# Altium schematic sheet FPGA.SchDoc
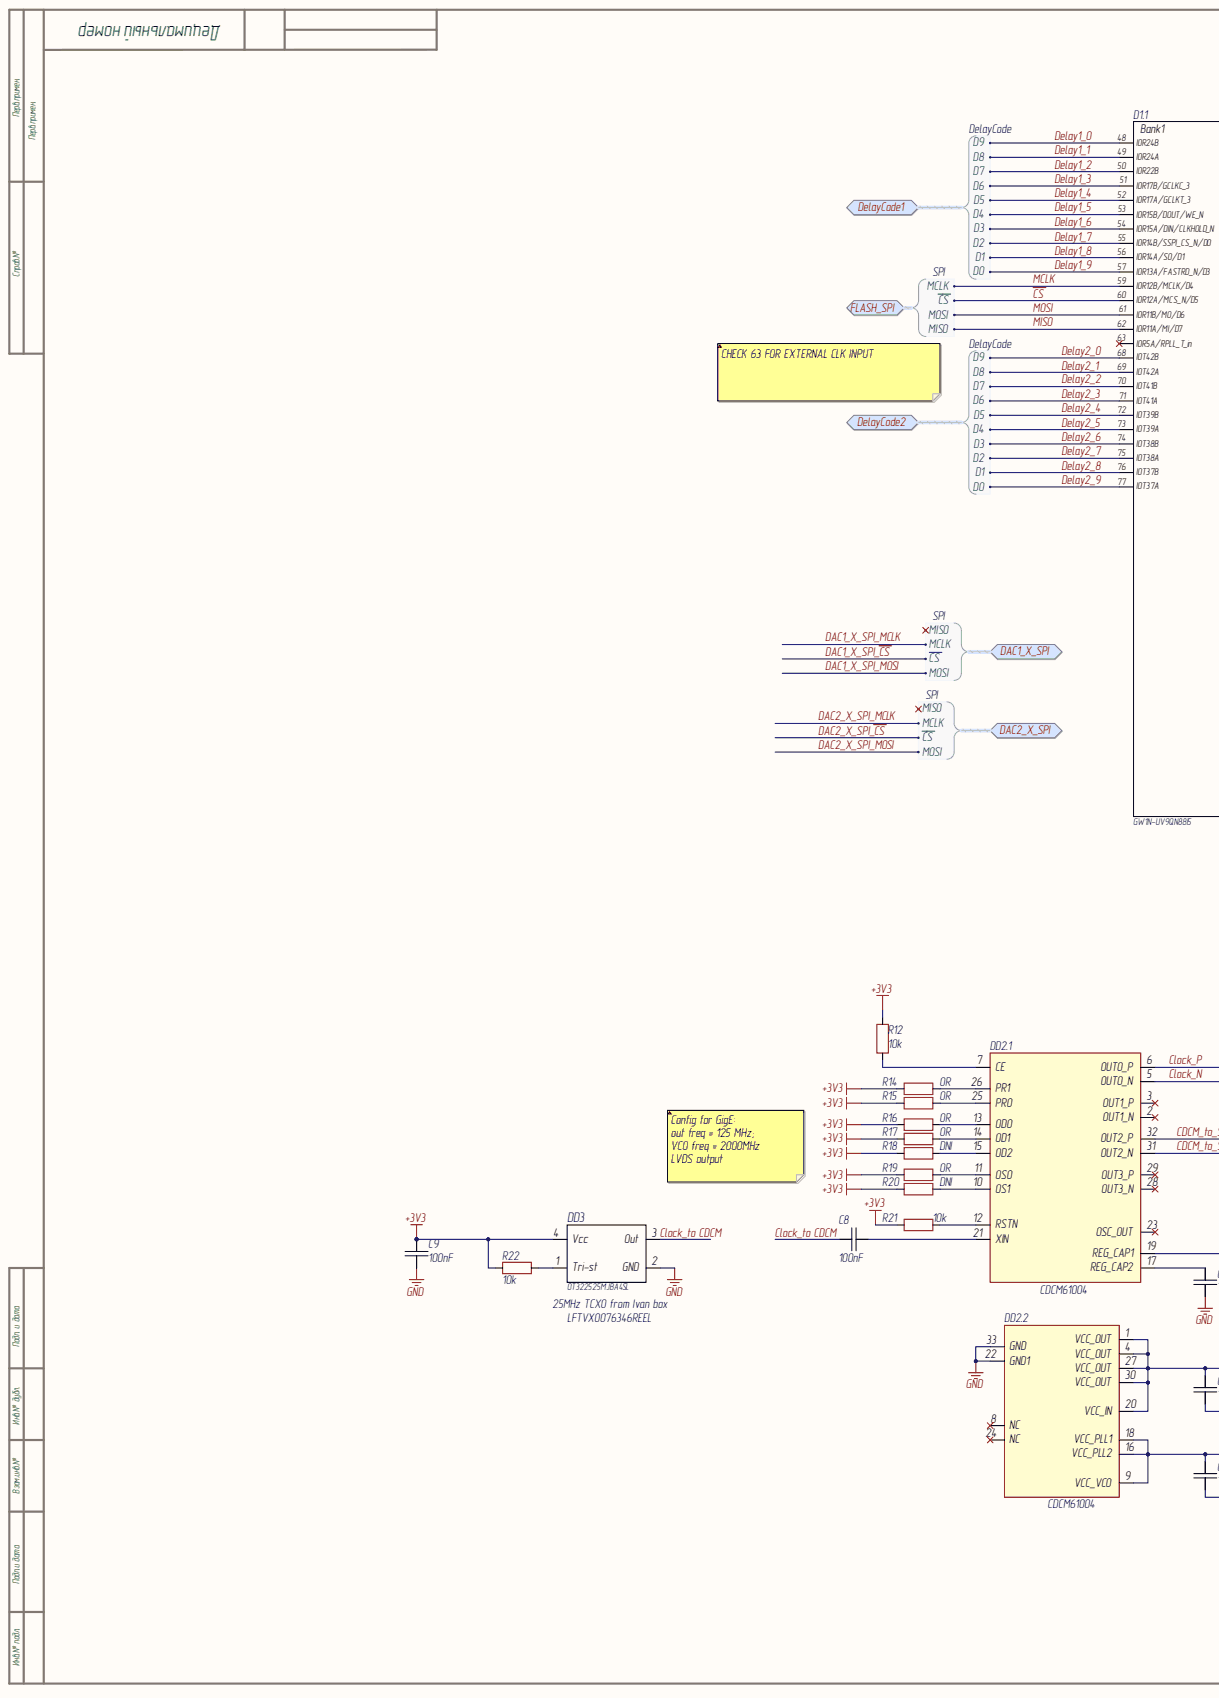
[diagram p0: FPGA.SchDoc, left]
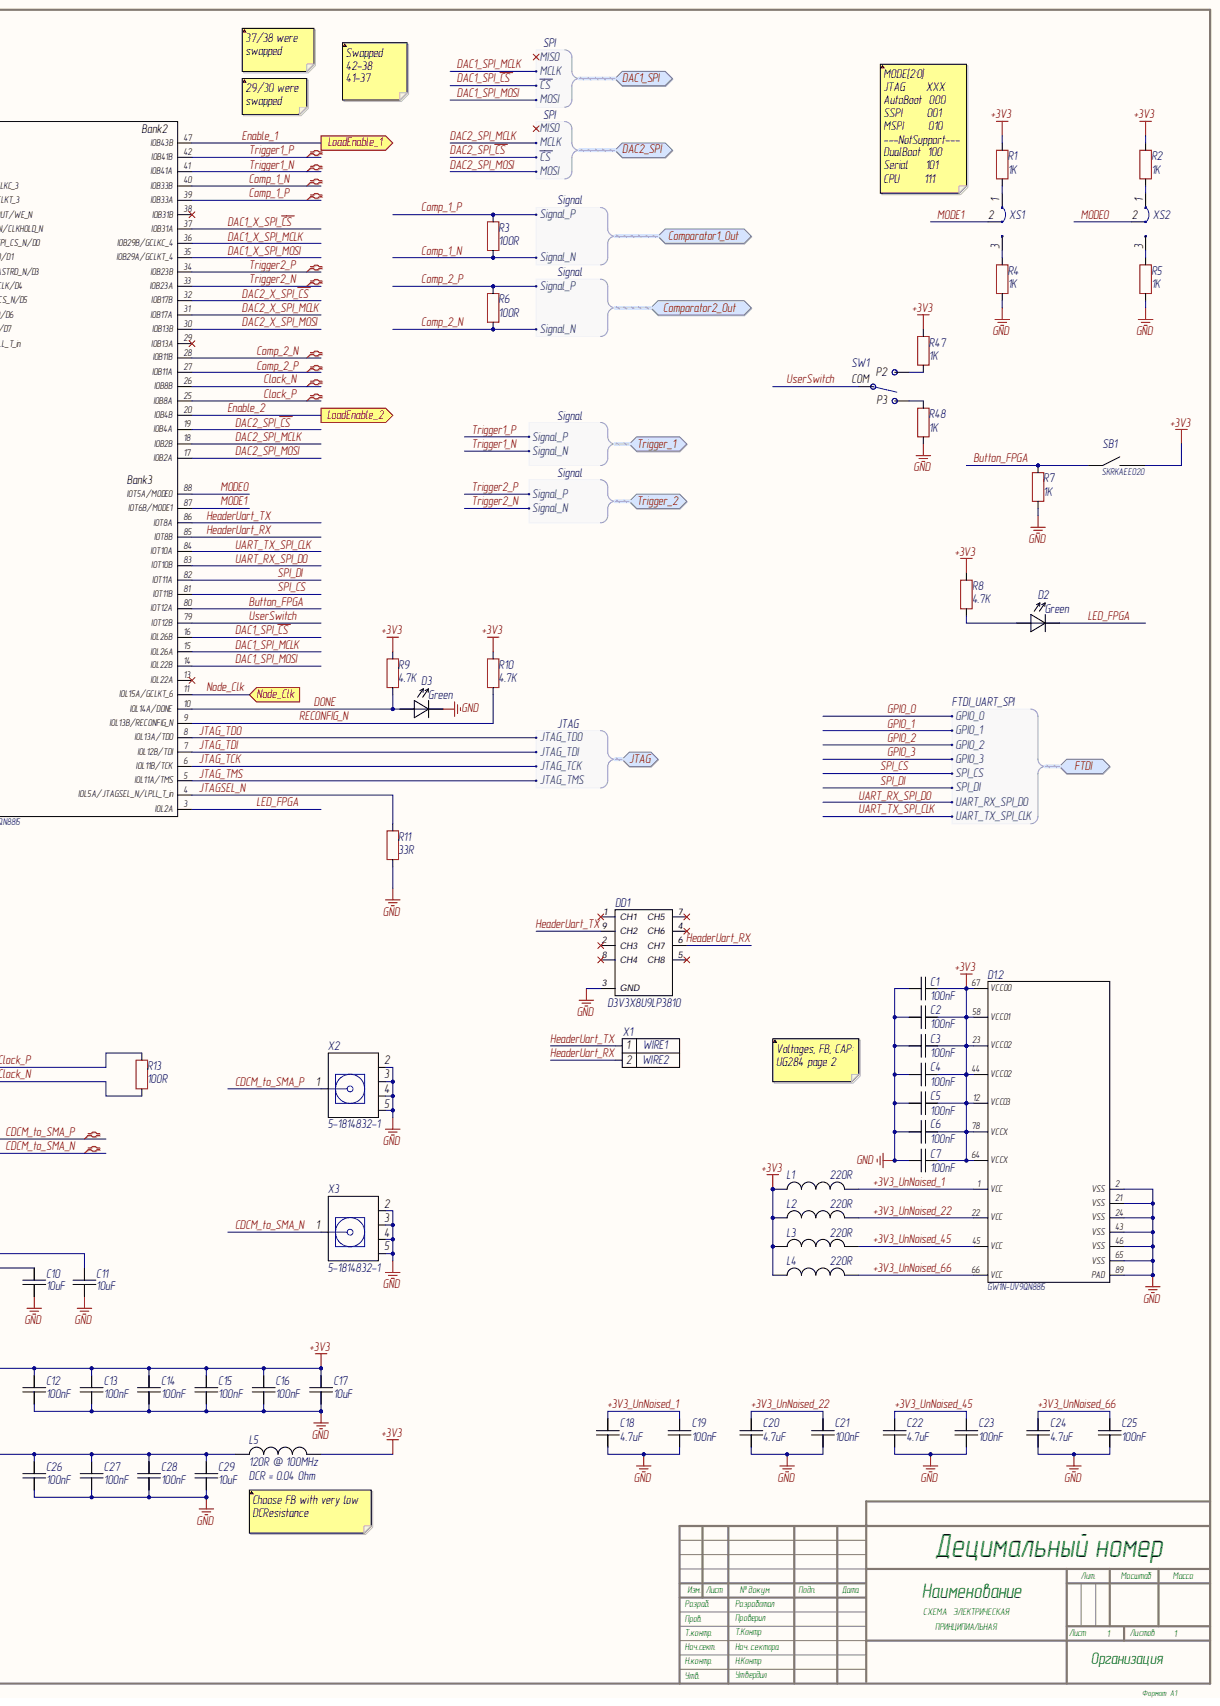
[diagram p1: FPGA.SchDoc, right]

## Parts
C1  value 100nF  [CAP0402]  (hidden comment: 100nF 50V)
C2  value 100nF  [CAP0402]  (hidden comment: 100nF 50V)
C3  value 100nF  [CAP0402]  (hidden comment: 100nF 50V)
C4  value 100nF  [CAP0402]  (hidden comment: 100nF 50V)
C5  value 100nF  [CAP0402]  (hidden comment: 100nF 50V)
C6  value 100nF  [CAP0402]  (hidden comment: 100nF 50V)
C7  value 100nF  [CAP0402]  (hidden comment: 100nF 50V)
C8  value 100nF  [CAP0402]  (hidden comment: 100nF 50V)
C9  value 100nF  [CAP0402]  (hidden comment: 100nF 50V)
C10  value 10uF  [CAPC0603N]  (hidden comment: 10uF 10V)
C11  value 10uF  [CAPC0603N]  (hidden comment: 10uF 10V)
C12  value 100nF  [CAP0402]  (hidden comment: 100nF 50V)
C13  value 100nF  [CAP0402]  (hidden comment: 100nF 50V)
C14  value 100nF  [CAP0402]  (hidden comment: 100nF 50V)
C15  value 100nF  [CAP0402]  (hidden comment: 100nF 50V)
C16  value 100nF  [CAP0402]  (hidden comment: 100nF 50V)
C17  value 10uF  [CAPC0603N]  (hidden comment: 10uF 10V)
C18  value 4.7uF  [CAP0402]  (hidden comment: 4.7uF 10V)
C19  value 100nF  [CAP0402]  (hidden comment: 100nF 50V)
C20  value 4.7uF  [CAP0402]  (hidden comment: 4.7uF 10V)
C21  value 100nF  [CAP0402]  (hidden comment: 100nF 50V)
C22  value 4.7uF  [CAP0402]  (hidden comment: 4.7uF 10V)
C23  value 100nF  [CAP0402]  (hidden comment: 100nF 50V)
C24  value 4.7uF  [CAP0402]  (hidden comment: 4.7uF 10V)
C25  value 100nF  [CAP0402]  (hidden comment: 100nF 50V)
C26  value 100nF  [CAP0402]  (hidden comment: 100nF 50V)
C27  value 100nF  [CAP0402]  (hidden comment: 100nF 50V)
C28  value 100nF  [CAP0402]  (hidden comment: 100nF 50V)
C29  value 10uF  [CAPC0603N]  (hidden comment: 10uF 10V)
D1  =Value  [QFN88_0.4pitch]  (parts .1,.2)
D2  Green  [LED603]
D3  Green  [LED603]
DD1  TVS Diode at JTAG  [U-DFN3810-9]  (value not drawn)
DD2  CDCM61004  [QFN-32_N_TP]  (parts .1,.2)
DD3  25MHz TCXO from Ivan box  value 25MHz  [TSX-3225_V]
L1  220R  [CAPC0603N]
L2  220R  [CAPC0603N]
L3  220R  [CAPC0603N]
L4  220R  [CAPC0603N]
L5  DCR = 0.04 Ohm  value 120R @ 100MHz  [IND-0805_N]
R1  value 1K  [RESC0402(1005)_M]  (hidden comment: 1K 1/16W)
R2  value 1K  [RESC0402(1005)_M]  (hidden comment: 1K 1/16W)
R3  100R  [RESC0402(1005)_M]
R4  value 1K  [RESC0402(1005)_M]  (hidden comment: 1K 1/16W)
R5  value 1K  [RESC0402(1005)_M]  (hidden comment: 1K 1/16W)
R6  100R  [RESC0402(1005)_M]
R7  1K  [RESC0402(1005)_M]
R8  4.7K  [RESC0402(1005)_M]
R9  4.7K  [RESC0402(1005)_M]
R10  4.7K  [RESC0402(1005)_M]
R11  value 33R  [RESC0402(1005)_M]  (hidden comment: 33R 1/16W)
R12  10k  [RESC0402(1005)_M]
R13  100R  [RESC0402(1005)_M]
R14  0R  [RESC0402(1005)_M]
R15  0R  [RESC0402(1005)_M]
R16  0R  [RESC0402(1005)_M]
R17  0R  [RESC0402(1005)_M]
R18  DNI  [RESC0402(1005)_M]
R19  0R  [RESC0402(1005)_M]
R20  DNI  [RESC0402(1005)_M]
R21  10k  [RESC0402(1005)_M]
R22  10k  [RESC0402(1005)_M]
R47  value 1K  [RESC0402(1005)_M]  (hidden comment: 1K 1/16W)
R48  value 1K  [RESC0402(1005)_M]  (hidden comment: 1K 1/16W)
SB1  DTSM-3  [SW_SKRKAEE020]  (value not drawn)
SW1  Slide Switch  [KLS7-SS03-12D02-EG-3.0]  (value not drawn)
X1  PLS2  [PLS2]  (value not drawn)
X2  5-1814832-1  [SMA_Vertical]
X3  5-1814832-1  [SMA_Vertical]
XS1  =Value  [jumper_2_mm _3_pin]  (no BOM)
XS2  =Value  [jumper_2_mm _3_pin]  (no BOM)

## Ports
Comparator1_Out, Comparator1_Out.Signal_N, Comparator1_Out.Signal_P, Comparator2_Out, Comparator2_Out.Signal_N, Comparator2_Out.Signal_P, DAC1_SPI, DAC1_SPI.MCLK, DAC1_SPI.MISO, DAC1_SPI.MOSI, DAC1_SPI~{.CS}, DAC1_X_SPI, DAC1_X_SPI.MCLK, DAC1_X_SPI.MISO, DAC1_X_SPI.MOSI, DAC1_X_SPI~{.CS}, DAC2_SPI, DAC2_SPI.MCLK, DAC2_SPI.MISO, DAC2_SPI.MOSI, DAC2_SPI~{.CS}, DAC2_X_SPI, DAC2_X_SPI.MCLK, DAC2_X_SPI.MISO, DAC2_X_SPI.MOSI, DAC2_X_SPI~{.CS}, DelayCode1, DelayCode1.D0, DelayCode1.D1, DelayCode1.D2, DelayCode1.D3, DelayCode1.D4, DelayCode1.D5, DelayCode1.D6, DelayCode1.D7, DelayCode1.D8, DelayCode1.D9, DelayCode2, DelayCode2.D0, DelayCode2.D1, DelayCode2.D2, DelayCode2.D3, DelayCode2.D4, DelayCode2.D5, DelayCode2.D6, DelayCode2.D7, DelayCode2.D8, DelayCode2.D9, FLASH_SPI, FLASH_SPI.MCLK, FLASH_SPI.MISO, FLASH_SPI.MOSI, FLASH_SPI~{.CS}, FTDI, FTDI.GPIO_0, FTDI.GPIO_1, FTDI.GPIO_2, FTDI.GPIO_3, FTDI.SPI_CS, FTDI.SPI_DI, FTDI.UART_RX_SPI_DO, FTDI.UART_TX_SPI_CLK, JTAG, JTAG.JTAG_TCK, JTAG.JTAG_TDI, JTAG.JTAG_TDO, JTAG.JTAG_TMS, LoadEnable_1, LoadEnable_2, Node_Clk, Trigger_1, Trigger_1.Signal_N, Trigger_1.Signal_P, Trigger_2, Trigger_2.Signal_N, Trigger_2.Signal_P

## Nets
+3V3: C1.1 C2.1 C3.1 C4.1 C5.1 C6.1 C7.1 C9.1 C12.2 C13.1 C14.1 C15.1 C16.1 C17.2 D1.12(VCCO3) D1.23(VCCO2) D1.44(VCCO2) D1.58(VCCO1) D1.64(VCCX) D1.67(VCCO0) D1.78(VCCX) DD2.1(VCC_OUT) DD2.4(VCC_OUT) DD2.20(VCC_IN) DD2.27(VCC_OUT) DD2.30(VCC_OUT) DD3.4(Vcc) L1.1 L2.1 L3.1 L4.1 L5.2 R1.2 R2.2 R8.2 R9.2 R10.2 R12.2 R14.1 R15.1 R16.1 R17.1 R18.1 R19.1 R20.1 R21.2 R22.2 R47.2 SB1.1
GND: C1.2 C2.2 C3.2 C4.2 C5.2 C6.2 C7.2 C9.2 C10.1 C11.2 C12.1 C13.2 C14.2 C15.2 C16.2 C17.1 C18.1 C19.2 C20.1 C21.2 C22.1 C23.2 C24.1 C25.2 C26.1 C27.2 C28.2 C29.1 D1.2(VSS) D1.21(VSS) D1.24(VSS) D1.43(VSS) D1.46(VSS) D1.65(VSS) D1.89(PAD) D3.1 DD1.3(GND) DD2.22(GND1) DD2.33(GND) DD3.2(GND) R4.1 R5.1 R7.1 R11.1 R48.1 X2.2 X2.3 X2.4 X2.5 X3.2 X3.3 X3.4 X3.5
+3V3_UnNoised_1: C18.2 C19.1 D1.1(VCC) L1.2
+3V3_UnNoised_22: C20.2 C21.1 D1.22(VCC) L2.2
+3V3_UnNoised_45: C22.2 C23.1 D1.45(VCC) L3.2
+3V3_UnNoised_66: C24.2 C25.1 D1.66(VCC) L4.2
~{CS}: D1.60(IOR12A/MCS_N/D5) ; port FLASH_SPI
Button_FPGA: D1.80(IOT12A) R7.2 SB1.2
CDCM_to_SMA_N: DD2.31(OUT2_N) X3.1
CDCM_to_SMA_P: DD2.32(OUT2_P) X2.1
Clock_N: D1.26(IOB8B) DD2.5(OUT0_N) R13.1
Clock_P: D1.25(IOB8A) DD2.6(OUT0_P) R13.2
Clock_to CDCM: C8.1 DD3.3(Out)
Comp_1_N: D1.40(IOB33B) R3.1 ; port Comparator1_Out
Comp_1_P: D1.39(IOB33A) R3.2 ; port Comparator1_Out
Comp_2_N: D1.28(IOB11B) R6.1 ; port Comparator2_Out
Comp_2_P: D1.27(IOB11A) R6.2 ; port Comparator2_Out
DAC1_SPI_~{CS}: D1.16(IOL26B) ; port DAC1_SPI
DAC1_SPI_MCLK: D1.15(IOL26A) ; port DAC1_SPI
DAC1_SPI_MOSI: D1.14(IOL22B) ; port DAC1_SPI
DAC1_X_SPI_~{CS}: D1.37(IOB31A) ; port DAC1_X_SPI
DAC1_X_SPI_MCLK: D1.36(IOB29B/GCLKC_4) ; port DAC1_X_SPI
DAC1_X_SPI_MOSI: D1.35(IOB29A/GCLKT_4) ; port DAC1_X_SPI
DAC2_SPI_~{CS}: D1.19(IOB4A) ; port DAC2_SPI
DAC2_SPI_MCLK: D1.18(IOB2B) ; port DAC2_SPI
DAC2_SPI_MOSI: D1.17(IOB2A) ; port DAC2_SPI
DAC2_X_SPI_~{CS}: D1.32(IOB17B) ; port DAC2_X_SPI
DAC2_X_SPI_MCLK: D1.31(IOB17A) ; port DAC2_X_SPI
DAC2_X_SPI_MOSI: D1.30(IOB13B) ; port DAC2_X_SPI
Delay1_0: D1.48(IOR24B) ; port DelayCode1
Delay1_1: D1.49(IOR24A) ; port DelayCode1
Delay1_2: D1.50(IOR22B) ; port DelayCode1
Delay1_3: D1.51(IOR17B/GCLKC_3) ; port DelayCode1
Delay1_4: D1.52(IOR17A/GCLKT_3) ; port DelayCode1
Delay1_5: D1.53(IOR15B/DOUT/WE_N) ; port DelayCode1
Delay1_6: D1.54(IOR15A/DIN/CLKHOLD_N) ; port DelayCode1
Delay1_7: D1.55(IOR14B/SSPI_CS_N/D0) ; port DelayCode1
Delay1_8: D1.56(IOR14A/SO/D1) ; port DelayCode1
Delay1_9: D1.57(IOR13A/FASTRD_N/D3) ; port DelayCode1
Delay2_0: D1.68(IOT42B) ; port DelayCode2
Delay2_1: D1.69(IOT42A) ; port DelayCode2
Delay2_2: D1.70(IOT41B) ; port DelayCode2
Delay2_3: D1.71(IOT41A) ; port DelayCode2
Delay2_4: D1.72(IOT39B) ; port DelayCode2
Delay2_5: D1.73(IOT39A) ; port DelayCode2
Delay2_6: D1.74(IOT38B) ; port DelayCode2
Delay2_7: D1.75(IOT38A) ; port DelayCode2
Delay2_8: D1.76(IOT37B) ; port DelayCode2
Delay2_9: D1.77(IOT37A) ; port DelayCode2
DONE: D1.10(IOL14A/DONE) D3.2 R9.1
Enable_1: D1.47(IOB43B) ; port LoadEnable_1
Enable_2: D1.20(IOB4B) ; port LoadEnable_2
HeaderUart_RX: D1.85(IOT8B) DD1.6(CH7) X1.2
HeaderUart_TX: D1.86(IOT8A) DD1.9(CH2) X1.1
JTAG_TCK: D1.6(IOL11B/TCK) ; port JTAG
JTAG_TDI: D1.7(IOL12B/TDI) ; port JTAG
JTAG_TDO: D1.8(IOL13A/TDO) ; port JTAG
JTAG_TMS: D1.5(IOL11A/TMS) ; port JTAG
JTAGSEL_N: D1.4(IOL5A/JTAGSEL_N/LPLL_T_in) R11.2
LED_FPGA: D1.3(IOL2A) D2.1
MCLK: D1.59(IOR12B/MCLK/D4) ; port FLASH_SPI
MISO: D1.62(IOR11A/MI/D7) ; port FLASH_SPI
MODE0: D1.88(IOT5A/MODE0) XS2.2
MODE1: D1.87(IOT6B/MODE1) XS1.2
MOSI: D1.61(IOR11B/MO/D6) ; port FLASH_SPI
Node_Clk: D1.11(IOL15A/GCLKT_6) ; port Node_Clk
RECONFIG_N: D1.9(IOL13B/RECONFIG_N) R10.1
SPI_CS: D1.81(IOT11B) ; port FTDI
SPI_DI: D1.82(IOT11A) ; port FTDI
Trigger1_N: D1.41(IOB41A) ; port Trigger_1
Trigger1_P: D1.42(IOB41B) ; port Trigger_1
Trigger2_N: D1.33(IOB23A) ; port Trigger_2
Trigger2_P: D1.34(IOB23B) ; port Trigger_2
UART_RX_SPI_DO: D1.83(IOT10B) ; port FTDI
UART_TX_SPI_CLK: D1.84(IOT10A) ; port FTDI
UserSwitch: D1.79(IOT12B) SW1.2
NetC8_2: C8.2 DD2.21(XIN)
NetC10_2: C10.2 DD2.17(REG_CAP2)
NetC11_1: C11.1 DD2.19(REG_CAP1)
NetC26_2: C26.2 C27.1 C28.1 C29.2 DD2.9(VCC_VCO) DD2.16(VCC_PLL2) DD2.18(VCC_PLL1) L5.1
NetD2_2: D2.2 R8.1
NetDD2_7: DD2.7(CE) R12.1
NetDD2_10: DD2.10(OS1) R20.2
NetDD2_11: DD2.11(OS0) R19.2
NetDD2_12: DD2.12(RSTN) R21.1
NetDD2_13: DD2.13(OD0) R16.2
NetDD2_14: DD2.14(OD1) R17.2
NetDD2_15: DD2.15(OD2) R18.2
NetDD2_25: DD2.25(PR0) R15.2
NetDD2_26: DD2.26(PR1) R14.2
NetDD3_1: DD3.1(Tri-st) R22.1
NetR1_1: R1.1 XS1.1
NetR2_1: R2.1 XS2.1
NetR4_2: R4.2 XS1.3
NetR5_2: R5.2 XS2.3
NetR47_1: R47.1 SW1.1
NetR48_2: R48.2 SW1.3
GPIO_0: ; port FTDI
GPIO_1: ; port FTDI
GPIO_2: ; port FTDI
GPIO_3: ; port FTDI
N00001: ; port DAC1_X_SPI
N00002: ; port DAC2_SPI
N00003: ; port DAC2_X_SPI
N00004: ; port DAC1_SPI

Not connected: D1 13(IOL22A) 29(IOB13A) 38(IOB31B) 63(IOR5A/RPLL_T_in); DD1 1(CH1) 2(CH3) 4(CH6) 5(CH8) 7(CH5) 8(CH4); DD2 2(OUT1_N) 3(OUT1_P) 8(NC) 23(OSC_OUT) 24(NC) 28(OUT3_N) 29(OUT3_P)

## Library data of the parts
C1-C9 C12-C16 C19 C21 C23 C25-C28 = CAP0402: Manufacturer: Samsung Electro-Mechanics; ManufacturerAlt: JLCPCB; MPN: CL05B104KB54PNC; MPNAlt: C307331; BOMDescription: SMD CHIP CERAMIC CAPACITOR CAP0402 100nF 10% 50V  CL05B104KB54PNC; Database Table Name: Symbol_SchLib; MntType: SMD; Notes: Basic Part; Tolerance: 10%; CFootprint: CAP0402; ClassName: Capacitor; Component Kind: Standard; ComponentLink1Description: Datasheet; ComponentLink1URL: https://datasheet.lcsc.com/lcsc/1810191222_Samsung-Electro-Mechanics-CL05B104KB54PNC_C307331.pdf; Height: 0.5mm; Library Name: Library.DbLib; MSL: MSL 1; Package: CAP0402; Part Number: CAP0402; PartType: CHIP CERAMIC CAPACITOR; Pins: 2; RoHS: Comply; Voltage: 50V; Weight: 1.500mg
C10 C11 C17 C29 = CAPC: Manufacturer: Samsung Electro-Mechanics; ManufacturerAlt: JLCPCB; MPN: CL10A106KP8NNNC; MPNAlt: C19702; BOMDescription: SMD CHIP CERAMIC CAPACITOR CAPC0603N 10uF 10% 10V  CL10A106KP8NNNC; Database Table Name: Symbol_SchLib; MntType: SMD; Tolerance: 10%; CFootprint: CAPC0603N; ClassName: Capacitor; Component Kind: Standard; ComponentLink1Description: Datasheet; ComponentLink1URL: https://datasheet.lcsc.com/lcsc/1810191219_Samsung-Electro-Mechanics-CL10A106KP8NNNC_C19702.pdf; Library Name: Library.DbLib; MSL: MSL 1; Package: CAPC0603N; Part Number: CAPC; PartType: CHIP CERAMIC CAPACITOR; Pins: 2; RoHS: Comply; Voltage: 10V
C18 C20 C22 C24 = CAP0402: Manufacturer: Samsung Electro-Mechanics; ManufacturerAlt: JLCPCB; MPN: CL05A475MP5NRNC; MPNAlt: C23733; BOMDescription: SMD CHIP CERAMIC CAPACITOR CAP0402 4.7uF 20% 10V  CL05A475MP5NRNC; Database Table Name: Symbol_SchLib; MntType: SMD; Tolerance: 20%; CFootprint: CAP0402; ClassName: Capacitor; Component Kind: Standard; ComponentLink1Description: Datasheet; ComponentLink1URL: https://datasheet.lcsc.com/lcsc/1810191211_Samsung-Electro-Mechanics-CL05A475MP5NRNC_C23733.pdf; Height: 0.5mm; Library Name: Library.DbLib; MSL: MSL 1; Package: CAP0402; Part Number: CAP0402; PartType: CHIP CERAMIC CAPACITOR; Pins: 2; RoHS: Comply; Voltage: 10V; Weight: 1.500mg
D1 = GW1N-UV9QN88I5: Manufacturer: GoWin; Database Table Name: Symbol_SchLib; MntType: SMD; BOMDescription: GW1N-UV9QN88I5; CFootprint: QFN88_0.4pitch; ClassName: FPGA; Component Kind: Standard; ComponentLink1Description: Datasheet; ComponentLink1URL: https://www.gowinsemi.com/en/support/database/?support_search=DS100; Library Name: Library.DbLib; MPN: GW1N-UV9QN88I5; MSL: MSL 1; Package: QFN88; Part Number: GW1N-UV9QN88I5; PartType: FPGA; Pins: 88; RoHS: Comply
D2 D3 = LEDC: Manufacturer: Everlight Elec; ManufacturerAlt: JLCPCB; MPN: 19-217/GHC-YR1S2/3T; MPNAlt: C72043; BOMDescription: SMD CHIP LED LED603 Green    19-217/GHC-YR1S2/3T; Database Table Name: Symbol_SchLib; MntType: SMD; CFootprint: LED603; ClassName: LED; Component Kind: Standard; ComponentLink1Description: Datasheet; Library Name: Library.DbLib; MSL: MSL 5; Package: LED603; Part Number: LEDC; PartType: CHIP LED; Pins: 2; RoHS: Comply; Value: Green
DD1 = D3V3X8U9LP3810: Manufacturer: DiodesInc; Database Table Name: Symbol_SchLib; MntType: SMD; BOMDescription: D3V3X8U9LP3810; CFootprint: U-DFN3810-9; ClassName: TVS; Component Kind: Standard; ComponentLink1Description: Datasheet; ComponentLink1URL: https://www.diodes.com/assets/Datasheets/D3V3X8U9LP3810.pdf; Library Name: Library.DbLib; MPN: D3V3X8U9LP3810; MSL: MSL 1; Package: U-DFN3810-9; Part Number: D3V3X8U9LP3810; PartType: TVS; Pins: 9; RoHS: Comply
DD2 = CDCM61004: Manufacturer: Texas Instruments; BOMDescription: SMD PLL VQFN32 =Value    CDCM61004; Database Table Name: Symbol_SchLib; MntType: SMD; CFootprint: QFN-32_N_TP; ClassName: PLL; Component Kind: Standard; ComponentLink1Description: Datasheet; ComponentLink1URL: https://www.ti.com/lit/ds/symlink/cdcm61004.pdf; Library Name: Library.DbLib; MPN: CDCM61004; MSL: MSL 1; Package: VQFN32; Part Number: CDCM61004; PartType: PLL; Pins: 32; RoHS: Comply
DD3 = OT322525MJBA4SL: Manufacturer: Yangxing Tech; Database Table Name: Symbol_SchLib; MntType: SMD; BOMDescription: OT322525MJBA4SL; CFootprint: TSX-3225_V; ClassName: Oscillator; Component Kind: Standard; ComponentLink1Description: Datasheet; ComponentLink1URL: https://datasheet.lcsc.com/lcsc/2103291238_Yangxing-Tech-OT322525MJBA4SL_C669088.pdf; Library Name: Library.DbLib; MPN: OT322525MJBA4SL; MSL: MSL 1; Package: SMD3225; Part Number: OT322525MJBA4SL; PartType: Oscillator; Pins: 4; RoHS: Comply
L1-L4 = BLM18KG221SN1D: Manufacturer: Murata Electronics; ManufacturerAlt: JLCPCB; MPNAlt: C88980; BOMDescription: SMD Ferrite Beads CAPC0603N 220R 25%   BLM18KG221SN1D; Database Table Name: Symbol_SchLib; MntType: SMD; Tolerance: 25%; CFootprint: CAPC0603N; ClassName: Ferrite Beads; Component Kind: Standard; ComponentLink1Description: Datasheet; ComponentLink1URL: https://ru.mouser.com/datasheet/2/281/ENFA0003-1915778.pdf; Height: 0.6mm; Library Name: Library.DbLib; MPN: BLM18KG221SN1D; MSL: MSL 1; Package: CAPC0603N; Part Number: BLM18KG221SN1D; PartType: Ferrite Beads; Pins: 2; RoHS: Comply; Value: 220R; Weight: 5mg
L5 = PBY201209T-121Y-N: Manufacturer: Chilisin Elec; ManufacturerAlt: JLCPCB; MPNAlt: C142063; BOMDescription: SMD INDUCTOR 0805 120R @ 100MHz    PBY201209T-121Y-N; Database Table Name: Symbol_SchLib; MntType: SMD; CFootprint: IND-0805_N; ClassName: Inductor; Component Kind: Standard; ComponentLink1Description: Datasheet; ComponentLink1URL: https://datasheet.lcsc.com/lcsc/1810261221_Chilisin-Elec-PBY201209T-121Y-N_C142063.pdf; Library Name: Library.DbLib; MPN: PBY201209T-121Y-N; MSL: MSL 1; Package: 0805; Part Number: PBY201209T-121Y-N; PartType: INDUCTOR; Pins: 2; RoHS: Comply
R1 R2 R4 R5 = RES0402: Manufacturer: UNI-ROYAL; ManufacturerAlt: JLCPCB; MPN: 0402WGF1001TCE; MPNAlt: C11702; BOMDescription: SMD CHIP RESISTOR RESC0402(1005)_M 1K 1% 50V 100ppm/C 0402WGF1001TCE; Database Table Name: Symbol_SchLib; MntType: SMD; TempCoeff: 100ppm/C; Tolerance: 1%; Voltage: 50V; CFootprint: RESC0402(1005)_M; ClassName: Resistor; Component Kind: Standard; ComponentLink1Description: Datasheet; ComponentLink1URL: https://datasheet.lcsc.com/lcsc/1811132311_UNI-ROYAL-Uniroyal-Elec-0402WGF1001TCE_C11702.pdf; Height: 0.35mm; Library Name: Library.DbLib; MSL: MSL 1; Package: RESC0402(1005)_M; Part Number: RES0402; PartType: CHIP RESISTOR; Pins: 2; Power: 1/16W; RoHS: Comply
R3 R6 R13 = RES0402: BOMDescription: SMD CHIP RESISTOR RESC0402(1005)_M 100R; Database Table Name: Symbol_SchLib; MntType: SMD; CFootprint: RESC0402(1005)_M; ClassName: Resistor; Component Kind: Standard; ComponentLink1Description: Datasheet; Library Name: Library.DbLib; MSL: MSL 1; Package: RESC0402(1005)_M; Part Number: RES0402; PartType: CHIP RESISTOR; Pins: 2; RoHS: Comply; Value: 100R
R7 = RES0402: BOMDescription: SMD CHIP RESISTOR RESC0402(1005)_M 1K; Database Table Name: Symbol_SchLib; MntType: SMD; CFootprint: RESC0402(1005)_M; ClassName: Resistor; Component Kind: Standard; ComponentLink1Description: Datasheet; Library Name: Library.DbLib; MSL: MSL 1; Package: RESC0402(1005)_M; Part Number: RES0402; PartType: CHIP RESISTOR; Pins: 2; RoHS: Comply; Value: 1K
R8-R10 = RES0402: Manufacturer: UNI-ROYAL; ManufacturerAlt: JLCPCB; MPN: 0402WGF4701TCE; MPNAlt: C25900; BOMDescription: SMD CHIP RESISTOR RESC0402(1005)_M 4.7K    0402WGF4701TCE; Database Table Name: Symbol_SchLib; MntType: SMD; CFootprint: RESC0402(1005)_M; ClassName: Resistor; Component Kind: Standard; ComponentLink1Description: Datasheet; Library Name: Library.DbLib; MSL: MSL 1; Package: RESC0402(1005)_M; Part Number: RES0402; PartType: CHIP RESISTOR; Pins: 2; RoHS: Comply; Value: 4.7K
R11 = RES0402: Manufacturer: UNI-ROYAL; ManufacturerAlt: JLCPCB; MPN: 0402WGF330JTCE; MPNAlt: C25105; BOMDescription: SMD CHIP RESISTOR RESC0402(1005)_M 33R 1% 50V 200ppm/C 0402WGF330JTCE; Database Table Name: Symbol_SchLib; MntType: SMD; Notes: Basic Part; TempCoeff: 200ppm/C; Tolerance: 1%; Voltage: 50V; CFootprint: RESC0402(1005)_M; ClassName: Resistor; Component Kind: Standard; ComponentLink1Description: Datasheet; ComponentLink1URL: https://datasheet.lcsc.com/lcsc/1810301314_UNI-ROYAL-Uniroyal-Elec-0402WGF330JTCE_C25105.pdf; Height: 0.35mm; Library Name: Library.DbLib; MSL: MSL 1; Package: RESC0402(1005)_M; Part Number: RES0402; PartType: CHIP RESISTOR; Pins: 2; Power: 1/16W; RoHS: Comply
R12 R21 R22 = RES0402: Manufacturer: UNI-ROYAL(Uniroyal Elec); ManufacturerAlt: JLCPCB; MPN: 0402WGF1002TCE; MPNAlt: C25744; BOMDescription: SMD CHIP RESISTOR RESC0402(1005)_M 10k    0402WGF1002TCE; Database Table Name: Symbol_SchLib; MntType: SMD; CFootprint: RESC0402(1005)_M; ClassName: Resistor; Component Kind: Standard; ComponentLink1Description: Datasheet; Library Name: Library.DbLib; MSL: MSL 1; Package: RESC0402(1005)_M; Part Number: RES0402; PartType: CHIP RESISTOR; Pins: 2; RoHS: Comply; Value: 10k
R14-R17 R19 = RES0402: Manufacturer: UNI-ROYAL(Uniroyal Elec); ManufacturerAlt: JLCPCB; MPN: 0402WGF0000TCE; MPNAlt: C17168; BOMDescription: SMD CHIP RESISTOR RESC0402(1005)_M 0R    0402WGF0000TCE; Database Table Name: Symbol_SchLib; MntType: SMD; CFootprint: RESC0402(1005)_M; ClassName: Resistor; Component Kind: Standard; ComponentLink1Description: Datasheet; ComponentLink1URL: https://datasheet.lcsc.com/lcsc/2110251730_UNI-ROYAL-Uniroyal-Elec-0402WGF0000TCE_C17168.pdf; Library Name: Library.DbLib; MSL: MSL 1; Package: RESC0402(1005)_M; Part Number: RES0402; PartType: CHIP RESISTOR; Pins: 2; RoHS: Comply; Value: 0R
R18 R20 = RES0402: Manufacturer: UNI-ROYAL(Uniroyal Elec); ManufacturerAlt: JLCPCB; MPN: 0402WGF0000TCE; MPNAlt: C17168; BOMDescription: SMD CHIP RESISTOR RESC0402(1005)_M DNI    0402WGF0000TCE; Database Table Name: Symbol_SchLib; MntType: SMD; CFootprint: RESC0402(1005)_M; ClassName: Resistor; Component Kind: Standard; ComponentLink1Description: Datasheet; ComponentLink1URL: https://datasheet.lcsc.com/lcsc/2110251730_UNI-ROYAL-Uniroyal-Elec-0402WGF0000TCE_C17168.pdf; Library Name: Library.DbLib; MSL: MSL 1; Package: RESC0402(1005)_M; Part Number: RES0402; PartType: CHIP RESISTOR; Pins: 2; RoHS: Comply; Value: DNI
R47 R48 = RES0402: Manufacturer: UNI-ROYAL; ManufacturerAlt: JLCPCB; MPN: 0402WGF1001TCE; MPNAlt: C11702; BOMDescription: SMD CHIP RESISTOR RESC0402(1005)_M 1K 1% 50V 100ppm/C 0402WGF1001TCE; Database Table Name: Symbol_SchLib.csv; MntType: SMD; TempCoeff: 100ppm/C; Tolerance: 1%; Voltage: 50V; CFootprint: RESC0402(1005)_M; ClassName: Resistor; Component Kind: Standard; ComponentLink1Description: Datasheet; ComponentLink1URL: https://datasheet.lcsc.com/lcsc/1811132311_UNI-ROYAL-Uniroyal-Elec-0402WGF1001TCE_C11702.pdf; Height: 0.35mm; Library Name: Library.DbLib; MSL: MSL 1; Package: RESC0402(1005)_M; Part Number: RES0402; PartType: CHIP RESISTOR; Pins: 2; Power: 1/16W; RoHS: Comply
SB1 = SKRKAEE020: Database Table Name: Symbol_SchLib; MntType: SMD; BOMDescription: SKRKAEE020; CFootprint: SW_SKRKAEE020; ClassName: Button; Component Kind: Standard; ComponentLink1Description: Datasheet; ComponentLink1URL: https://datasheet.lcsc.com/lcsc/1809201020_ALPSALPINE-SKRKAEE020_C115357.pdf; Library Name: Library.DbLib; MPN: SKRKAEE020; MSL: MSL 1; Part Number: SKRKAEE020; PartType: BUTTON; Pins: 2; RoHS: Comply
SW1 = KLS7-SS03-12D02-EG-3.0: Toggle Switch SPDT Through Hole, Right Angle; MANUFACTURER: KLS Electronic; BOMDESCRIPTION: TH Slide_Switch KLS7-SS03-12D02-EG-3.0 =Value    KLS7-SS03-12D02-EG-3.0; Database Table Name: Symbol_SchLib.csv; MNTTYPE: TH; CFOOTPRINT: KLS7-SS03-12D02-EG-3.0; CLASSNAME: Switch; Component Kind: Standard; COMPONENTLINK1DESCRIPTION: Datasheet; COMPONENTLINK1URL: http://www.cnkls.com/admin/product_upload/20120515151849KLS7-SS03-12D02.pdf; Library Name: Library.DbLib; MPN: KLS7-SS03-12D02-EG-3.0; MSL: MSL 1; PACKAGE: KLS7-SS03-12D02-EG-3.0; PART NUMBER: KLS7-SS03-12D02-EG-3.0; PARTTYPE: Slide_Switch; PINS: 3; ROHS: Comply
X1 = PLS2: BOMDescription: DIP CONNECTOR CONNPLS2     PLS2; Database Table Name: Symbol_SchLib; MntType: DIP; System: Connector; CFootprint: PLS2; ClassName: Connector; Component Kind: Standard; ComponentLink1Description: Datasheet; Library Name: Library.DbLib; MPN: PLS2; MSL: MSL 1; Package: CONNPLS2; Part Number: PLS2; PartType: CONNECTOR; Pins: 2; RoHS: Comply; Wire1: WIRE1; Wire2: WIRE2
X2 X3 = SMA_Connector_Straight: MANUFACTURER: TI; BOMDESCRIPTION: TH CONNECTOR SMA_Vertical =Value    5-1814832-1; Database Table Name: Symbol_SchLib.csv; MNTTYPE: TH; CFOOTPRINT: SMA_Vertical; CLASSNAME: Connector; Component Kind: Standard; COMPONENTLINK1DESCRIPTION: Datasheet; COMPONENTLINK1URL: https://www.te.com/usa-en/product-5-1814832-1.datasheet.pdf; Library Name: Library.DbLib; MPN: 5-1814832-1; MSL: MSL 1; PACKAGE: SMA_Vertical; PART NUMBER: SMA_Connector_Straight; PARTTYPE: CONNECTOR; PINS: 5; ROHS: Comply; SYSTEM: Connector
XS1 XS2 = Jumper_2_mm_3_pin: BOMDescription: DIP CONNECTOR  =Value    Jumper_2_mm _3_pin; Database Table Name: Symbol_SchLib; MntType: DIP; System: Connector; CFootprint: jumper_2_mm _3_pin; ClassName: Connector; ComponentLink1Description: Datasheet; ComponentLink1URL: https://static.chipdip.ru/lib/245/DOC000245332.pdf; Library Name: Library.DbLib; MPN: Jumper_2_mm _3_pin; MSL: MSL 1; Part Number: Jumper_2_mm_3_pin; PartType: CONNECTOR; Pins: 3; RoHS: Comply
